# Altium schematic sheet InterfaceAndControl.SchDoc
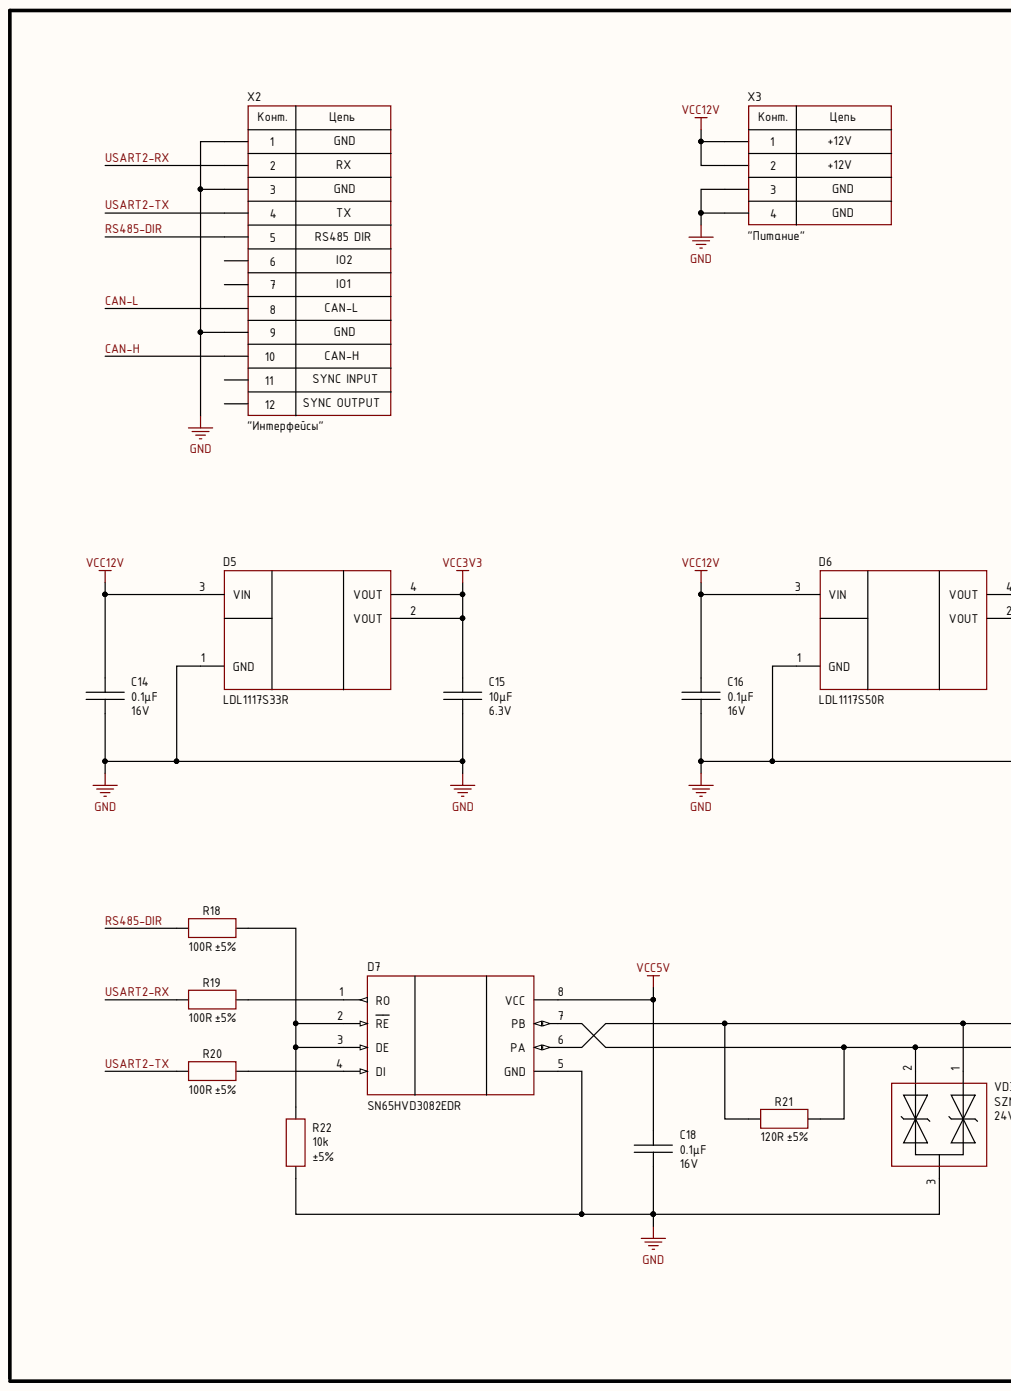
[diagram p0: InterfaceAndControl.SchDoc, left]
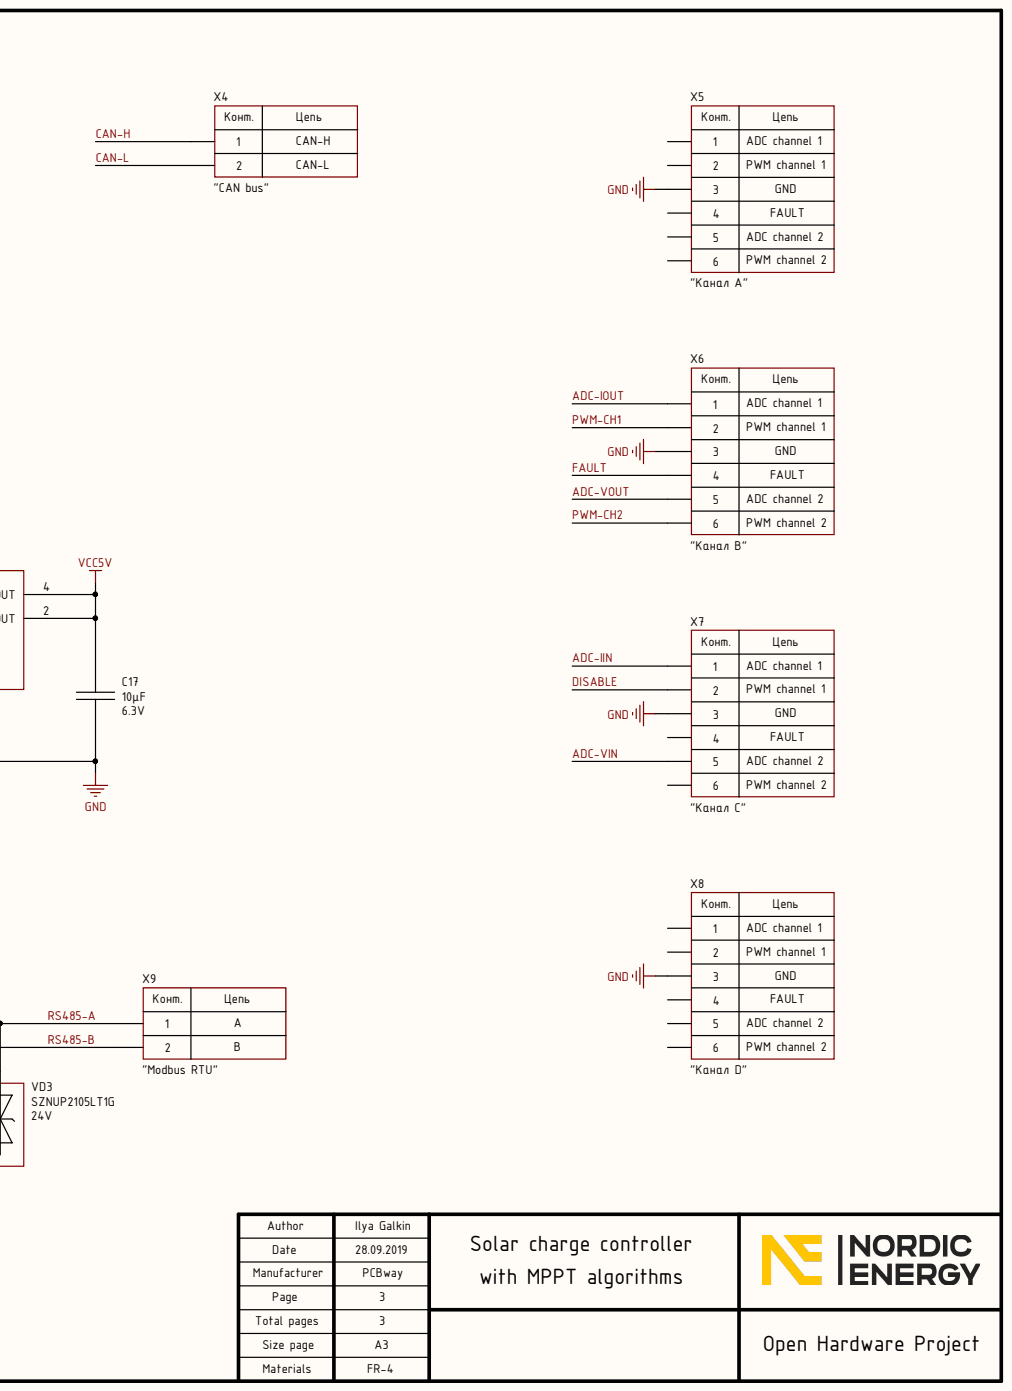
[diagram p1: InterfaceAndControl.SchDoc, right]

## Parts
C14  value 0.1μF  Voltage: 16V  [CAP: 0805]  (hidden comment: Ceramic capacitor, 0.1μF, X7R, ±10%, 16V, 0805, -55...+125°C)
C15  value 10μF  Voltage: 6.3V  [CAP: 0805]  (hidden comment: Ceramic capacitor, 10μF, X7R, ±10%, 6.3V, 0805, -55...+125°C)
C16  value 0.1μF  Voltage: 16V  [CAP: 0805]  (hidden comment: Ceramic capacitor, 0.1μF, X7R, ±10%, 16V, 0805, -55...+125°C)
C17  value 10μF  Voltage: 6.3V  [CAP: 0805]  (hidden comment: Ceramic capacitor, 10μF, X7R, ±10%, 6.3V, 0805, -55...+125°C)
C18  value 0.1μF  Voltage: 16V  [CAP: 0805]  (hidden comment: Ceramic capacitor, 0.1μF, X7R, ±10%, 16V, 0805, -55...+125°C)
D5  Linear voltage regulator, input 3.9...18V, 0.6V, output 3.3V, 1.2A, -40...+85°C, SOT223  [SOT223]  (value not drawn)
D6  PartNumber: LDL1117S50R  [SOT223]  (hidden comment: Linear voltage regulator, input 5.6...18V, 0.6V, output 5V, 1.2A, -40...+85°C, SOT-223)
D7  IC RS485 transceiver, 200 kbps, Half-duplex, 4.5...5.5V, -40...+85°C, 8-SOIC  [8-SOIC]  (value not drawn)
R18  value 100R  Tolerance: ±5%  [RES: 0805]  (hidden comment: Resistor film, 100R, ±5%, 0805, 0.125W, -55...+125°C)
R19  value 100R  Tolerance: ±5%  [RES: 0805]  (hidden comment: Resistor film, 100R, ±5%, 0805, 0.125W, -55...+125°C)
R20  value 100R  Tolerance: ±5%  [RES: 0805]  (hidden comment: Resistor film, 100R, ±5%, 0805, 0.125W, -55...+125°C)
R21  value 120R  Tolerance: ±5%  [RES: 0805]  (hidden comment: Resistor film, 120R, ±5%, 0805, 0.125W, -55...+125°C)
R22  value 10k  Tolerance: ±5%  [RES: 0805]  (hidden comment: Resistor film, 10k, ±5%, 0805, 0.125W, -55...+125°C)
VD3  PartNumber: SZNUP2105LT1G  Voltage: 24V  [SOT23]  (hidden comment: TVS diode, 24V, 350W peak, 0.9V, 30pF, SOT-23, -55...+150°C)
X2  CommentText: "Интерфейсы"  [CON: PBD-12]  (hidden comment: Connector, 12pin, 2.54mm, 500VAC, 3A)
X3  CommentText: "Питание"  [CON: PBD-4]  (hidden comment: Connector, 4pin, 2.54mm, 500VAC, 3A)
X4  CommentText: "CAN bus"  [CON: DG350-3.5-02P]  (hidden comment: Terminal connector, 2pin, 3.5mm, 300VAC, 10A)
X5  CommentText: "Канал А"  [CON: PBD-6]  (hidden comment: Connector, 6pin, 2.54mm, 500VAC, 3A)
X6  CommentText: "Канал В"  [CON: PBD-6]  (hidden comment: Connector, 6pin, 2.54mm, 500VAC, 3A)
X7  CommentText: "Канал С"  [CON: PBD-6]  (hidden comment: Connector, 6pin, 2.54mm, 500VAC, 3A)
X8  CommentText: "Канал D"  [CON: PBD-6]  (hidden comment: Connector, 6pin, 2.54mm, 500VAC, 3A)
X9  CommentText: "Modbus RTU"  [CON: DG350-3.5-02P]  (hidden comment: Terminal connector, 2pin, 3.5mm, 300VAC, 10A)

## Nets
GND: C14.1 C15.1 C16.1 C17.1 C18.1 D5.1(GND) D6.1(GND) D7.5(GND) R22.2 VD3.3 X2.1 X2.3 X2.9 X3.3 X3.4 X5.3 X6.3 X7.3 X8.3
VCC3V3: C15.2 D5.2(VOUT) D5.4(VOUT)
VCC5V: C17.2 C18.2 D6.2(VOUT) D6.4(VOUT) D7.8(VCC)
VCC12V: C14.2 C16.2 D5.3(VIN) D6.3(VIN) X3.1 X3.2
ADC-IIN: X7.1
ADC-IOUT: X6.1
ADC-VIN: X7.5
ADC-VOUT: X6.5
CAN-H: X2.10 X4.1
CAN-L: X2.8 X4.2
DISABLE: X7.2
FAULT: X6.4
PWM-CH1: X6.2
PWM-CH2: X6.6
RS485-A: D7.6(PA) R21.1 VD3.1 X9.1
RS485-B: D7.7(PB) R21.2 VD3.2 X9.2
RS485-DIR: R18.1 X2.5
USART2-RX: R19.1 X2.2
USART2-TX: R20.1 X2.4
NetD7_1: D7.1(RO) R19.2
NetD7_2: D7.2(RE) D7.3(DE) R18.2 R22.1
NetD7_4: D7.4(DI) R20.2

Not connected: X2 6 7 11 12; X5 1 2 4 5 6; X7 4 6; X8 1 2 4 5 6

## Library data of the parts
C14 C16 C18 = Ceramic_0805: Manufacturer: Yageo; PartNumber: CC0805KRX7R7BB104; Component Kind: Standard; HelpURL: https://www.digikey.com/product-detail/en/yageo/CC0805KRX7R7BB104/311-1142-1-ND/303052; Library Name: Capacitor.IntLib; Package: 0805; Temperature: -55...+125°C; Tolerance: ±10%; TypeCapacitor: Ceramic capacitor; TypeDielectric: X7R; ValueManufacturerPartNumber: Ceramic capacitor, 0.1μF, X7R, ±10%, 16V, 0805, -55...+125°C
C15 C17 = Ceramic_0805: Manufacturer: Samsung; PartNumber: CL21B106KQQNNNE; Component Kind: Standard; HelpURL: https://www.digikey.com/product-detail/en/samsung-electro-mechanics/CL21B106KQQNNNE/1276-1113-1-ND/3889199; Library Name: Capacitor.IntLib; Package: 0805; Temperature: -55...+125°C; Tolerance: ±10%; TypeCapacitor: Ceramic capacitor; TypeDielectric: X7R; ValueManufacturerPartNumber: Ceramic capacitor, 10μF, X7R, ±10%, 6.3V, 0805, -55...+125°C
D5 D6 = LDL1117S33R: Linear voltage regulator; Manufacturer: STMicroelectronics; Component Kind: Standard; ComponentType: Linear voltage regulator; CurrentOutput: 1.2A; Library Name: IC. Power supply.IntLib; MaxDropout: 0.6V; Temperature: -40...+85°C
  D5: HelpURL: https://www.digikey.com/product-detail/en/stmicroelectronics/LDL1117S33R/497-17239-1-ND/7102079; Package: SOT223; PartNumber: LDL1117S33R; ValueManufacturerPartNumber: Linear voltage regulator, input 3.9...18V, 0.6V, output 3.3V, 1.2A, -40...+85°C, SOT223; VoltageInput: input 3.9...18V; VoltageOutput: output 3.3V
  D6: HelpURL: https://www.digikey.com/product-detail/en/stmicroelectronics/LDL1117S50R/497-17240-6-ND/7102088; Package: SOT-223; ValueManufacturerPartNumber: Linear voltage regulator, input 5.6...18V, 0.6V, output 5V, 1.2A, -40...+85°C, SOT-223; VoltageInput: input 5.6...18V; VoltageOutput: output 5V
D7 = SN65HVD3082EDR: RS485; Manufacturer: Texas Instruments; Component Kind: Standard; ComponentType: IC RS485 transceiver; DataRate: 200 kbps; HelpURL: https://www.digikey.com/product-detail/en/texas-instruments/SN65HVD3082EDR/296-31719-1-ND/3505195; Library Name: IC. Interface.IntLib; Package: 8-SOIC; PartNumber: SN65HVD3082EDR; Supply: 4.5...5.5V; Temperature: -40...+85°C; TypeDuplex: Half-duplex; ValueManufacturerPartNumber: IC RS485 transceiver, 200 kbps, Half-duplex, 4.5...5.5V, -40...+85°C, 8-SOIC
R18-R20 = Resistor_0805: Manufacturer: Yageo; PartNumber: RC0805JR-07100RL; Component Kind: Standard; HelpURL: https://www.digikey.com/product-detail/en/yageo/RC0805JR-07100RL/311-100ARCT-ND/731185; Library Name: Resistor.IntLib; Package: 0805; Power: 0.125W; Temperature: -55...+125°C; TypeResistor: Resistor film; ValueManufacturerPartNumber: Resistor film, 100R, ±5%, 0805, 0.125W, -55...+125°C
R21 = Resistor_0805: Manufacturer: Yageo; PartNumber: RC0805JR-07120RL; Component Kind: Standard; HelpURL: https://www.digikey.com/product-detail/en/yageo/RC0805JR-07120RL/311-120ARCT-ND/731194; Library Name: Resistor.IntLib; Package: 0805; Power: 0.125W; Temperature: -55...+125°C; TypeResistor: Resistor film; ValueManufacturerPartNumber: Resistor film, 120R, ±5%, 0805, 0.125W, -55...+125°C
R22 = Resistor_0805: Manufacturer: Yageo; PartNumber: RC0805JR-0710KL; Component Kind: Standard; HelpURL: https://www.digikey.com/product-detail/en/yageo/RC0805JR-0710KL/311-10KARCT-ND/731188; Library Name: Resistor.IntLib; Package: 0805; Power: 0.125W; Temperature: -55...+125°C; TypeResistor: Resistor film; ValueManufacturerPartNumber: Resistor film, 10k, ±5%, 0805, 0.125W, -55...+125°C
VD3 = TVS_array: Manufacturer: ON Semiconductor; Component Kind: Standard; Current: 350W peak; HelpURL: https://www.digikey.com/product-detail/en/on-semiconductor/SZNUP2105LT1G/SZNUP2105LT1GOSCT-ND/3487669; Library Name: Diode.IntLib; Package: SOT-23; RecoveryTime: 30pF; Temperature: -55...+150°C; TypeDiode: TVS diode; ValueManufacturerPartNumber: TVS diode, 24V, 350W peak, 0.9V, 30pF, SOT-23, -55...+150°C; VoltageDrop: 0.9V
X2 = PBD-12: Manufacturer: Connfly; Component Kind: Standard; CurrentMax: 3A; HelpURL: https://www.chipdip.ru/product/pbd-12; Library Name: Connector.IntLib; Multichannel: 12pin; PartNumber: PBD-12; Pitch: 2.54mm; TypeConnector: Connector; ValueManufacturerPartNumber: Connector, 12pin, 2.54mm, 500VAC, 3A; VoltageMax: 500VAC
X3 = PBD-4: Manufacturer: Connfly; Component Kind: Standard; CurrentMax: 3A; HelpURL: https://www.chipdip.ru/product/pbd-4; Library Name: Connector.IntLib; Multichannel: 4pin; PartNumber: PBD-4; Pitch: 2.54mm; TypeConnector: Connector; ValueManufacturerPartNumber: Connector, 4pin, 2.54mm, 500VAC, 3A; VoltageMax: 500VAC
X4 X9 = Connector_terminal_2pin: Manufacturer: Degson; PartNumber: DG350-3.5-02P-14; Component Kind: Standard; CurrentMax: 10A; HelpURL: https://www.chipdip.ru/product/dg350-3.5-02p-12; Library Name: Connector.IntLib; Multichannel: 2pin; Pitch: 3.5mm; TypeConnector: Terminal connector; ValueManufacturerPartNumber: Terminal connector, 2pin, 3.5mm, 300VAC, 10A; VoltageMax: 300VAC
X5-X8 = PBD-6: Manufacturer: Connfly; Component Kind: Standard; CurrentMax: 3A; HelpURL: https://www.chipdip.ru/product/pbd-6; Library Name: Connector.IntLib; Multichannel: 6pin; PartNumber: PBD-6; Pitch: 2.54mm; TypeConnector: Connector; ValueManufacturerPartNumber: Connector, 6pin, 2.54mm, 500VAC, 3A; VoltageMax: 500VAC
